# Altium schematic sheet SDEC945-xB_Backlight.SchDoc(SDEC945-xB_Backlight)
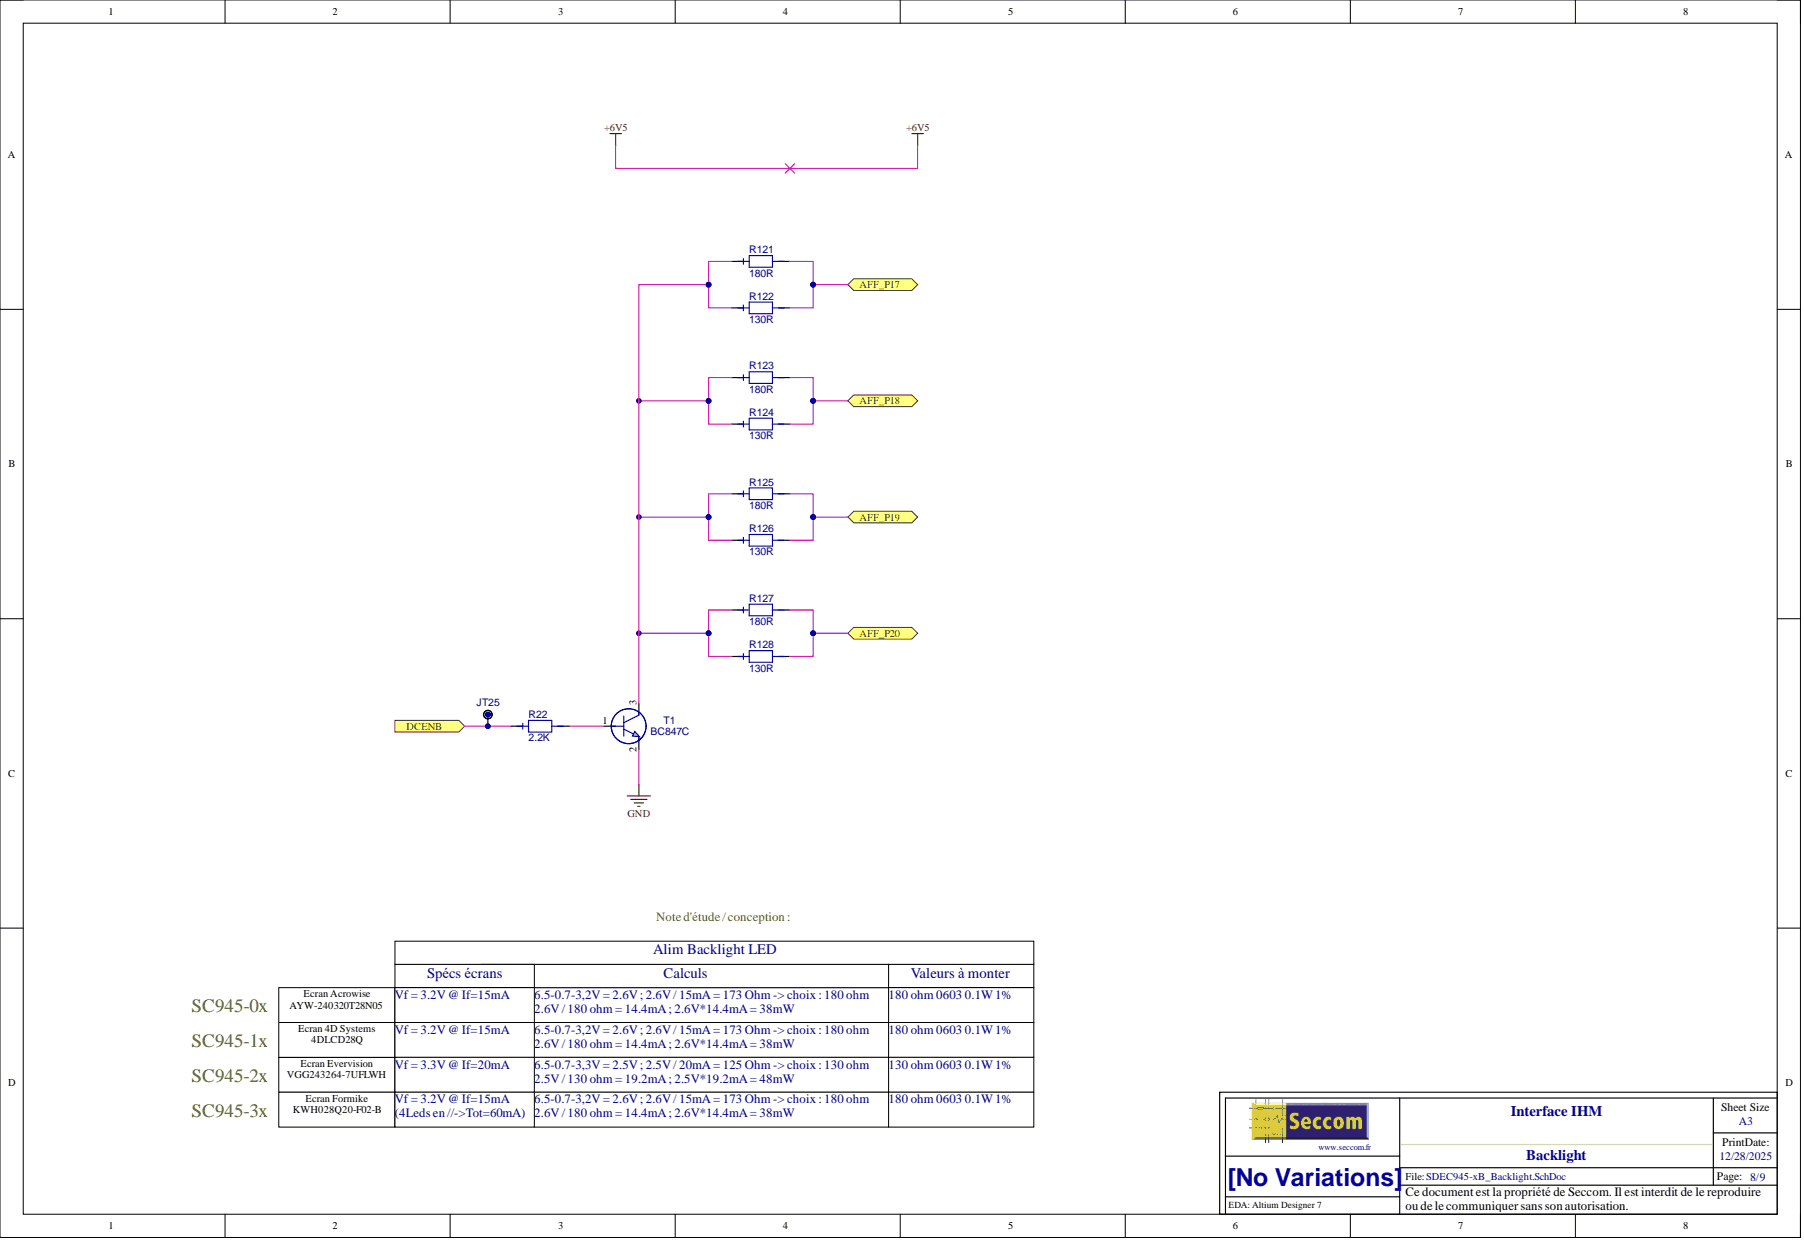
[diagram p0: SDEC945-xB_Backlight.SchDoc]

## Parts
JT25  POINT_TEST_PAD_40MIL  (value not drawn)  (no BOM)
R22  value 2.2K  (hidden comment: RES_0603_1%_100PPM_2.2K)
R121  value 180R  (hidden comment: RES_0603_1%_100PPM_180R)
R122  value 130R  (hidden comment: RES_0603_1%_100PPM_130R)
R123  value 180R  (hidden comment: RES_0603_1%_100PPM_180R)
R124  value 130R  (hidden comment: RES_0603_1%_100PPM_130R)
R125  value 180R  (hidden comment: RES_0603_1%_100PPM_180R)
R126  value 130R  (hidden comment: RES_0603_1%_100PPM_130R)
R127  value 180R  (hidden comment: RES_0603_1%_100PPM_180R)
R128  value 130R  (hidden comment: RES_0603_1%_100PPM_130R)
T1  BC847C

## Ports
AFF_P17, AFF_P18, AFF_P19, AFF_P20, DCENB

## Nets
GND: T1.2
AFF_P17: R121.2 R122.2 ; port AFF_P17
AFF_P18: R123.2 R124.2 ; port AFF_P18
AFF_P19: R125.2 R126.2 ; port AFF_P19
AFF_P20: R127.2 R128.2 ; port AFF_P20
DCENB: JT25.1 R22.1 ; port DCENB
NetR22_2: R22.2 T1.1
NetR121_1: R121.1 R122.1 R123.1 R124.1 R125.1 R126.1 R127.1 R128.1 T1.3
+6V5:

## Library data of the parts
JT25 = POINT_TEST_PAD_40MIL: Point de test sur CI, pad de diamètre 1.016mm (40mils); Coeff: 1; Creation: FB (04/10/12); Remarque: {Remarque}; ComponentLink1Description: Datasheet; ComponentLink2Description: Datasheet Boitier; Value: POINT_TEST; Library Name: CONN_PICOT.SchLib
R22 = RES_0603_1%_100PPM_2.2K: Résistance, 0.100W, 1%, 100ppm, 75V, 2.2K; Boitier: 0603; Coeff: 1; Creation: JPL (05/07/12); Fabricant: Vishay; Ref Fab: CRCW06032K20FKEA; Remarque: {Remarque}; Component Kind: Standard; ComponentLink1Description: Datasheet; ComponentLink2Description: Datasheet Boitier; Library Name: SC94x.SchLib; Supplier 1: Farnell; Supplier 2: RadioSpares; Supplier Part Number 1: 1469765; Supplier Part Number 2: 6790112; ComponentLink1URL: O:\_Documentation\Datasheets\Vishay\Resistances_D-CRCWe3.pdf; ComponentLink2URL: o:\_Documentation\Datasheets\_BOITIERS\PASSIF_CMS\
R121 R123 R125 R127 = RES_0603_1%_100PPM_180R: Résistance, 0.100W, 1%, 100ppm, 75V, 180R; Boitier: 0603; Coeff: 1; Creation: FB (06/09/12); Fabricant: Vishay; Ref Fab: CRCW0603180RFKEA; Remarque: {Remarque}; Component Kind: Standard; ComponentLink1Description: Datasheet; ComponentLink2Description: Datasheet Boitier; Library Name: SC94x.SchLib; Supplier 1: Farnell; Supplier 2: Mouser; Supplier Part Number 1: 1469762; Supplier Part Number 2: 71-CRCW0603-180-E3; ComponentLink1URL: O:\_Documentation\Datasheets\Vishay\Resistances_D-CRCWe3.pdf; ComponentLink2URL: o:\_Documentation\Datasheets\_BOITIERS\PASSIF_CMS\
R122 R124 R126 R128 = RES_0603_1%_100PPM_130R: Résistance, 0.100W, 1%, 100ppm, 75V, 130R; Boitier: 0603; Coeff: 1; Creation: FB (10/09/12); Fabricant: Vishay; Ref Fab: CRCW0603130RFKEA; Remarque: {Remarque}; Component Kind: Standard; ComponentLink1Description: Datasheet; ComponentLink2Description: Datasheet Boitier; Library Name: SC94x.SchLib; Supplier 1: Farnell; Supplier 2: Mouser; Supplier Part Number 1: 2141288; Supplier Part Number 2: 71-CRCW0603-130-E3; ComponentLink1URL: O:\_Documentation\Datasheets\Vishay\Resistances_D-CRCWe3.pdf; ComponentLink2URL: o:\_Documentation\Datasheets\_BOITIERS\PASSIF_CMS\
T1 = BC847C: Transistor NPN, Vce=50V, Ic=0.1A, hfe=420~800, 0.25W, CMS; Boitier: SOT23_3L; Coeff: 1; Creation: GO (08/07/05); Fabricant: NXP; Reference: 000329/00; Remarque: Complementaires aux BC856-860; Verification: LJ (21/07/05); Component Kind: Standard; ComponentLink1Description: Datasheet; ComponentLink2Description: Datasheet Boitier; Library Name: TRANSISTOR.SchLib; Ref Fab: BC847C; Supplier 1: Farnell; Supplier Part Number 1: 1081235; Value: BC847C; ComponentLink1URL: O:\_Documentation\Datasheets\NXP\BC847.pdf; ComponentLink2URL: o:\_Documentation\Datasheets\_BOITIERS\ACTIF_CMS\SOT\SOT_23_3P_(Schneider).PDF
